# Altium schematic sheet Sheet1.SchDoc
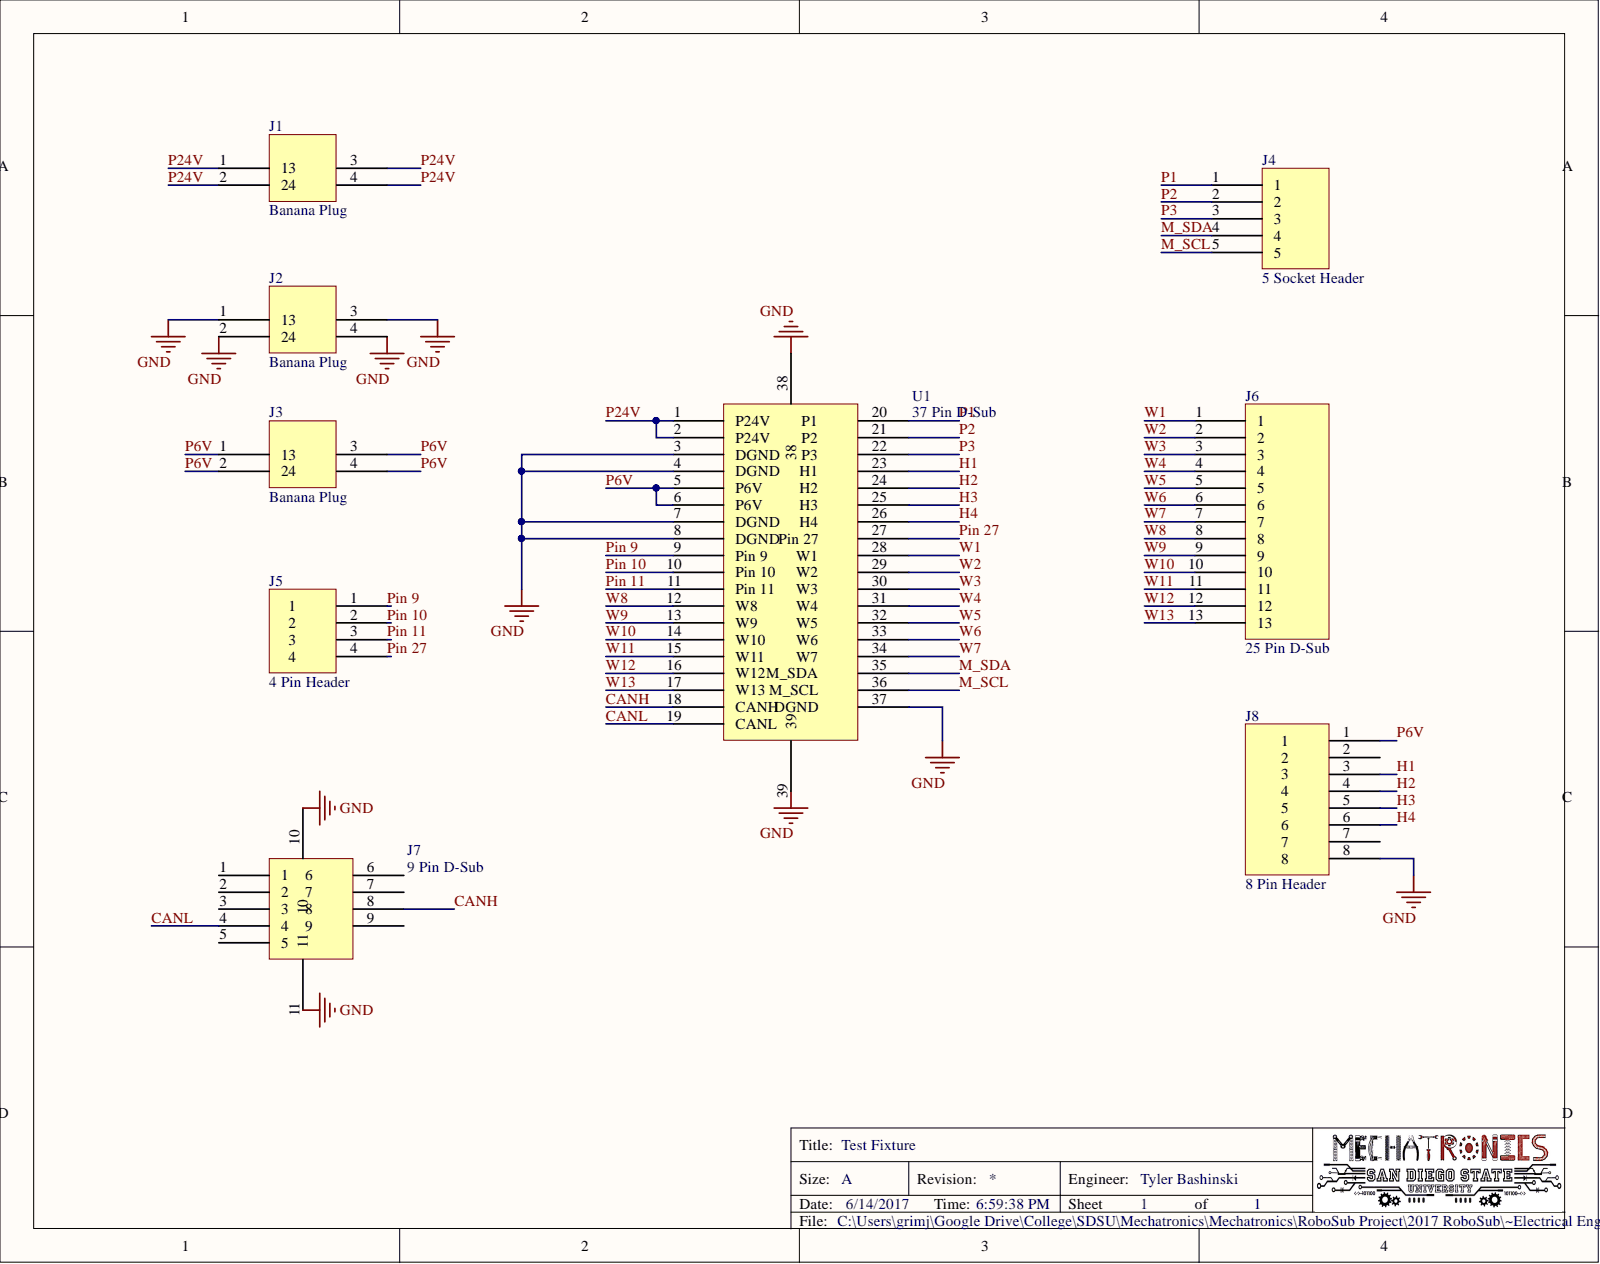
[diagram p0: Sheet1.SchDoc]

## Parts
J1  Banana Plug  [Banana Jack]
J2  Banana Plug  [Banana Jack]
J3  Banana Plug  [Banana Jack]
J4  5 Socket Header  [5 Socket Header]
J5  4 Pin Header  [4 Pin Header]
J6  25 Pin D-Sub  [25 Pin D-Sub]
J7  9 Pin D-Sub  [9 PIN D-SUB]
J8  8 Pin Header  [8 Pin Header]
U1  37 Pin D-Sub  [37 Pin D-Sub]

## Nets
GND: J2.1(1_3) J2.2(2_4) J2.3 J2.4 J7.10 J7.11 J8.8 U1.3 U1.4(DGND) U1.7(DGND) U1.8(DGND) U1.37 U1.38 U1.39
CANH: J7.8 U1.18(CANH)
CANL: J7.4 U1.19(CANL)
H1: J8.3 U1.23
H2: J8.4 U1.24
H3: J8.5 U1.25
H4: J8.6 U1.26
M_SCL: J4.5 U1.36
M_SDA: J4.4 U1.35
P1: J4.1 U1.20
P2: J4.2 U1.21
P3: J4.3 U1.22
P6V: J3.1(1_3) J3.2(2_4) J3.3 J3.4 J8.1 U1.5(P6V) U1.6(P6V)
P24V: J1.1(1_3) J1.2(2_4) J1.3 J1.4 U1.1(P24V) U1.2(P24V)
PIN 9: J5.1 U1.9
PIN 10: J5.2 U1.10
PIN 11: J5.3 U1.11
PIN 27: J5.4 U1.27
W1: J6.1 U1.28
W2: J6.2 U1.29
W3: J6.3 U1.30
W4: J6.4 U1.31
W5: J6.5 U1.32
W6: J6.6 U1.33
W7: J6.7 U1.34
W8: J6.8 U1.12(W8)
W9: J6.9 U1.13(W9)
W10: J6.10 U1.14(W10)
W11: J6.11 U1.15(W11)
W12: J6.12 U1.16
W13: J6.13 U1.17

Not connected: J7 1 2 3 5 6 7 9; J8 2 7

## Library data of the parts
J6 = 25  Pin D-Sub: Component Kind: Standard; Library Name: Schlib1.SchLib
J1-J3 = Banana Plug: Component Kind: Standard; Library Name: Schlib1.SchLib
J4 = 5 Socket Header: Component Kind: Standard; Library Name: Schlib1.SchLib
J5 = 4 Pin Header: Component Kind: Standard; Library Name: Schlib1.SchLib
J7 = 9 Pin D-Sub: Component Kind: Standard; Library Name: Schlib1.SchLib
J8 = 8 Pin Header: Component Kind: Standard; Library Name: Schlib1.SchLib
U1 = 37 Pin D-Sub: Component Kind: Standard; Library Name: Schlib1.SchLib
